# Altium schematic sheet SCH_WETBCV1XX.SchDoc(SCH_WETBCV1XX)
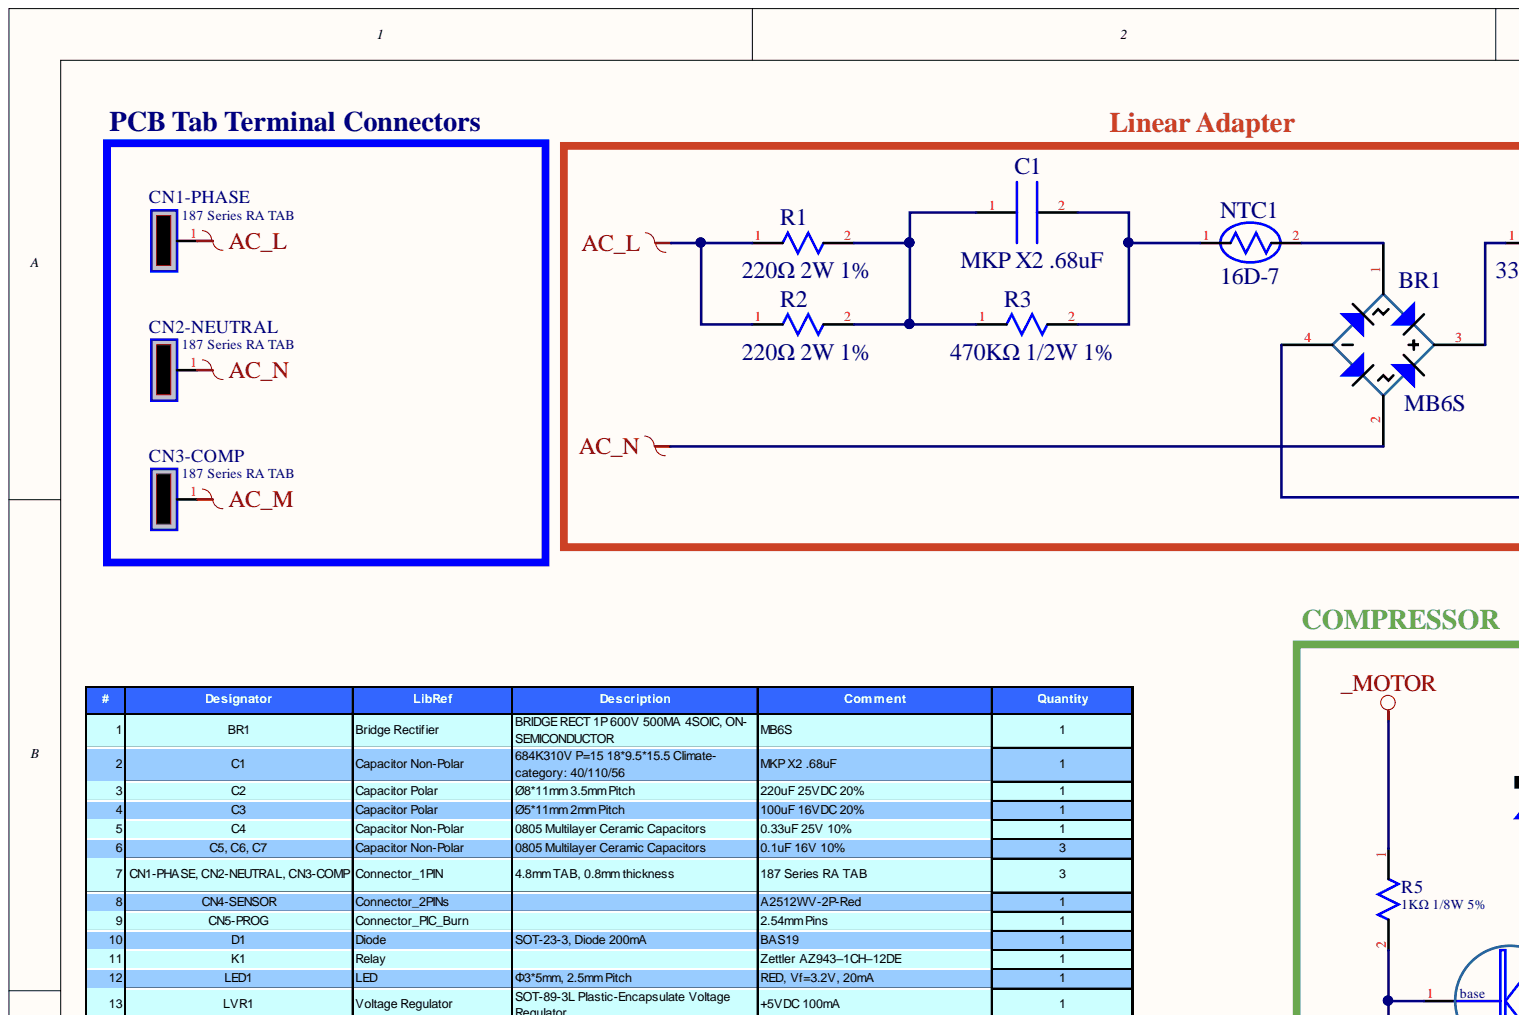
[diagram p0: SCH_WETBCV1XX.SchDoc, top left]
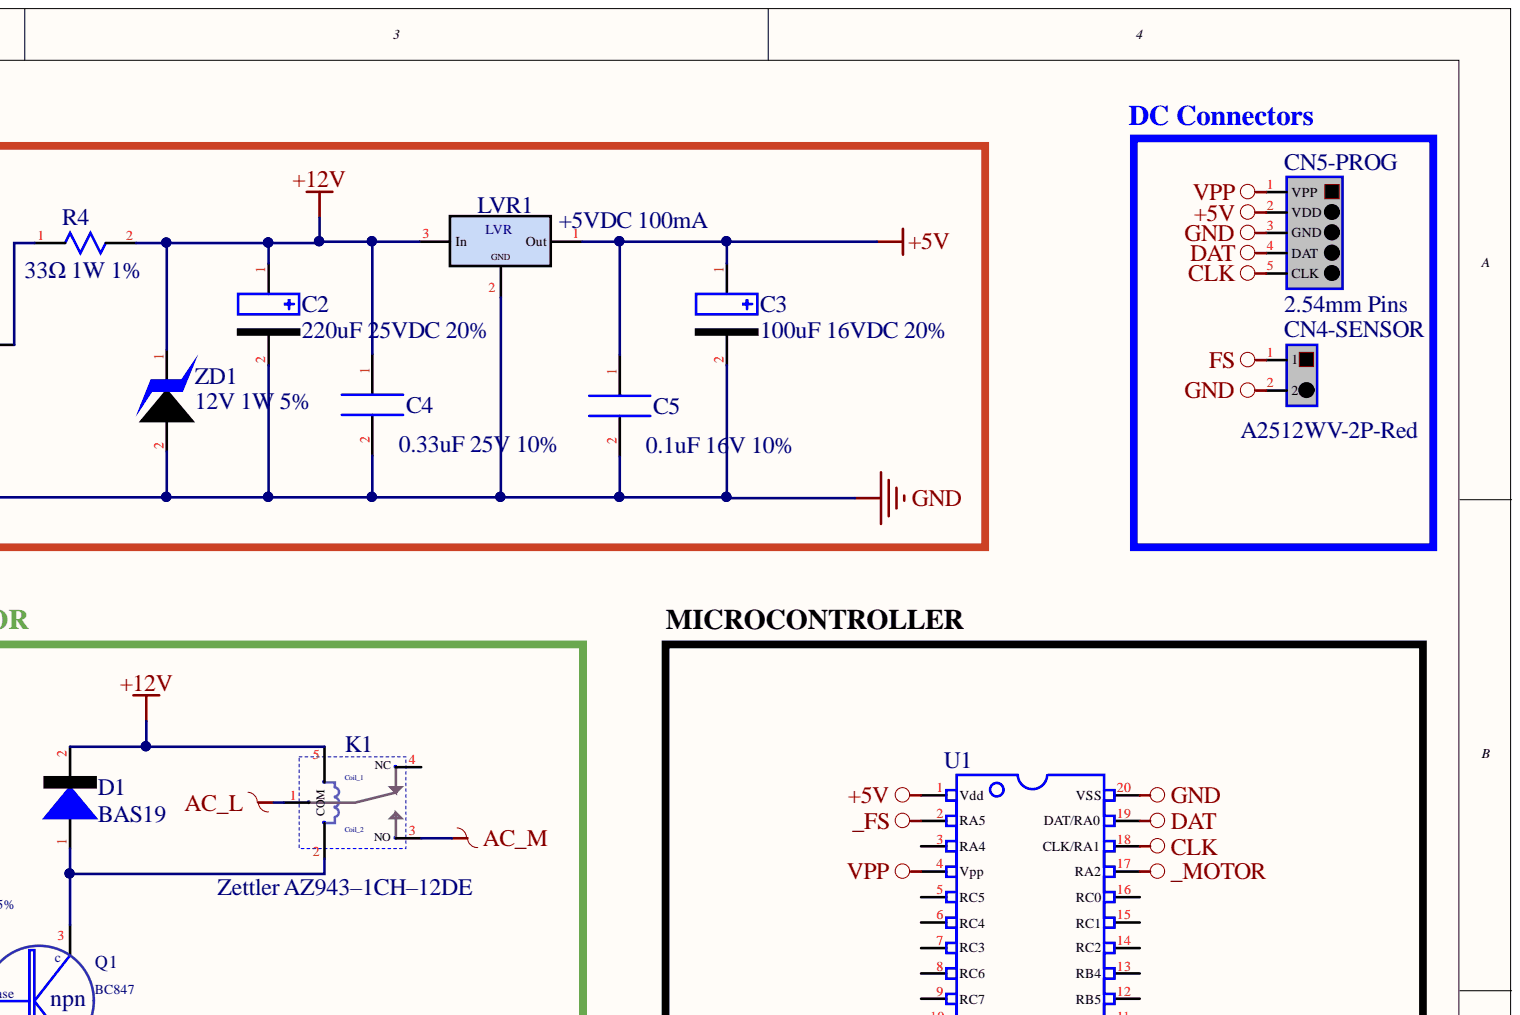
[diagram p1: SCH_WETBCV1XX.SchDoc, top right]
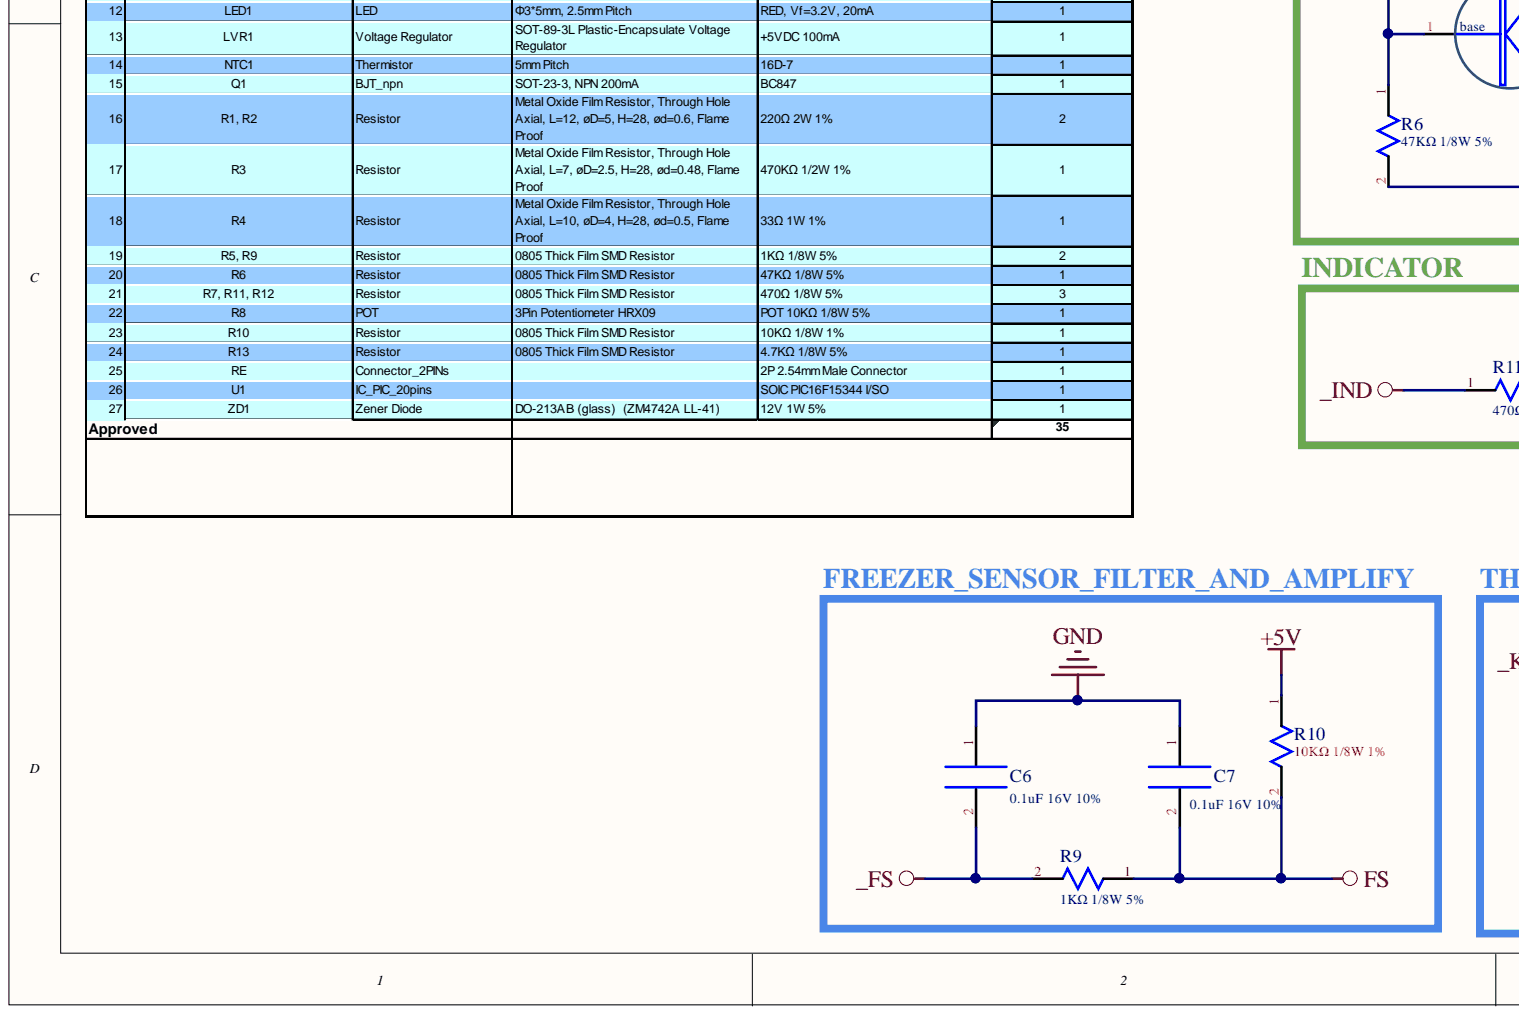
[diagram p2: SCH_WETBCV1XX.SchDoc, bottom left]
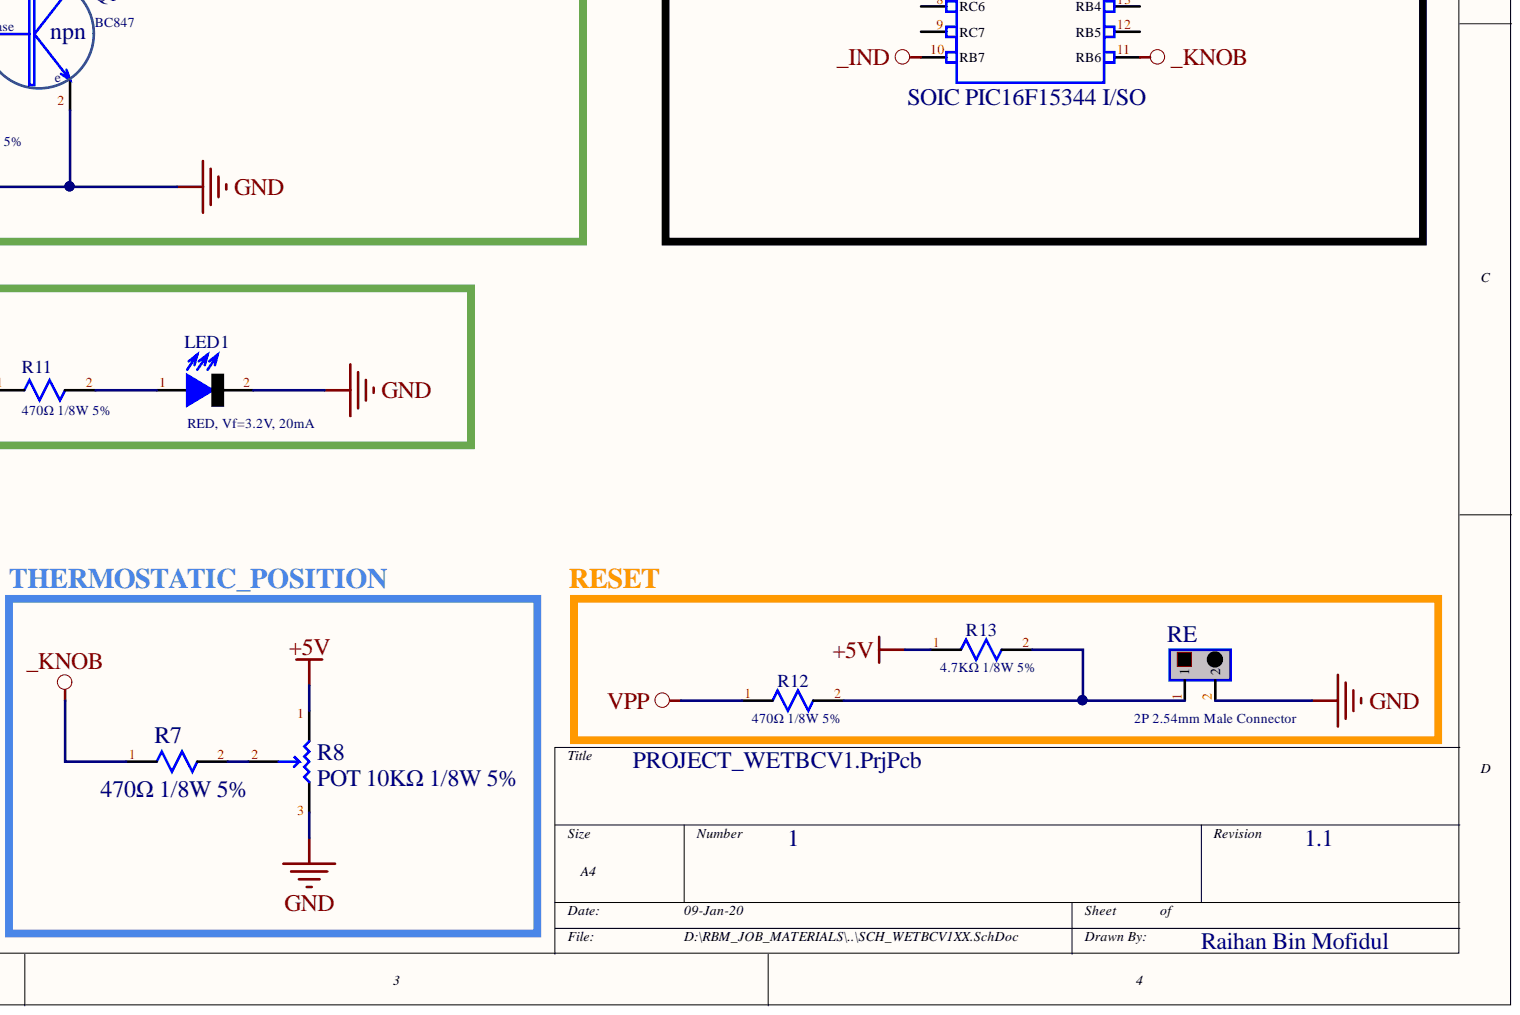
[diagram p3: SCH_WETBCV1XX.SchDoc, bottom right]

## Parts
BR1  MB6S  [BR_SMD_MB6S]
C1  MKP X2 .68uF  [C_X2_18X10X15.8_P15]
C2  220uF 25VDC 20%  [C_Radial_P3.5]
C3  100uF 16VDC 20%  [C_Radial_P2]
C4  0.33uF 25V 10%  [C_0805]
C5  0.1uF 16V 10%  [C_0805]
C6  0.1uF 16V 10%  [C_0805]
C7  0.1uF 16V 10%  [C_0805]
CN1-PHASE  187 Series RA TAB  [CN_Tab Terminal 187 series (Right Angle)]
CN2-NEUTRAL  187 Series RA TAB  [CN_Tab Terminal 187 series (Right Angle)]
CN3-COMP  187 Series RA TAB  [CN_Tab Terminal 187 series (Right Angle)]
CN4-SENSOR  A2512WV-2P-Red  [CN_B2B-XH-A_WHITE]
CN5-PROG  2.54mm Pins  [CN_PIC_MCU_Debugger_Straight]
D1  BAS19  [D_SOT-23-3_200mA]
K1  Zettler AZ943–1CH–12DE  [K_15ARelay_AZ943-1CH-12DE]
LED1  RED, Vf=3.2V, 20mA  [LED_3x5_P2.5]
LVR1  +5VDC 100mA  [U_CJ78L05_SOT89]
NTC1  16D-7  [NTC_5mmPitch]
Q1  BC847  [Q_SOT-23-3_NPN_BC847]
R1  220Ω 2W 1%  [R_Axial_P15]
R2  220Ω 2W 1%  [R_Axial_P15]
R3  470KΩ 1/2W 1%  [R_Axial_P10]
R4  33Ω 1W 1%  [R_Axial_P15]
R5  1KΩ 1/8W 5%  [R_0805]
R6  47KΩ 1/8W 5%  [R_0805]
R7  470Ω 1/8W 5%  [R_0805]
R8  POT 10KΩ 1/8W 5%  [R_POT]
R9  1KΩ 1/8W 5%  [R_0805]
R10  10KΩ 1/8W 1%  [R_0805]
R11  470Ω 1/8W 5%  [R_0805]
R12  470Ω 1/8W 5%  [R_0805]
R13  4.7KΩ 1/8W 5%  [R_0805]
RE  2P 2.54mm Male Connector  [CN_PIC_MCU_Reseter_Straight]
U1  SOIC PIC16F15344 I/SO  [U_PIC16F15344_SOIC]
ZD1  12V 1W 5%  [ZD_ZM4742A LL-41]

## Nets
+5V: C3.1 C5.1 CN5-PROG.2(VDD) LVR1.1 R8.1 R10.1 R13.1 U1.1(Vdd)
+12V: C2.1 C4.1 D1.2 K1.5 LVR1.3 R4.2 ZD1.1
_FS: C6.2 R9.2 U1.2(RA5)
_IND: R11.1 U1.10(RB7)
_KNOB: R7.1 U1.11(RB6)
_MOTOR: R5.1 U1.17(RA2)
AC_L: CN1-PHASE.1 K1.1 R1.1 R2.1
AC_M: CN3-COMP.1 K1.3(NO)
AC_N: BR1.2 CN2-NEUTRAL.1
CLK: CN5-PROG.5(CLK) U1.18(CLK/RA1)
DAT: CN5-PROG.4(DAT) U1.19(DAT/RA0)
FS: C7.2 CN4-SENSOR.1 R9.1 R10.2
GND: BR1.4 C2.2 C3.2 C4.2 C5.2 C6.1 C7.1 CN4-SENSOR.2 CN5-PROG.3(GND) LED1.2 LVR1.2 Q1.2 R6.2 R8.3 RE.2 U1.20(VSS) ZD1.2
VPP: CN5-PROG.1(VPP) R12.1 U1.4(Vpp)
NetBR1_1: BR1.1 NTC1.2
NetBR1_3: BR1.3 R4.1
NetC1_1: C1.1 R1.2 R2.2 R3.1
NetC1_2: C1.2 NTC1.1 R3.2
NetD1_1: D1.1 K1.2(COM) Q1.3
NetLED1_1: LED1.1 R11.2
NetQ1_1: Q1.1 R5.2 R6.1
NetR7_2: R7.2 R8.2
NetR12_2: R12.2 R13.2 RE.1

Not connected: K1 4(NC); U1 3(RA4) 5(RC5) 6(RC4) 7(RC3) 8(RC6) 9(RC7) 12(RB5) 13(RB4) 14(RC2) 15(RC1) 16(RC0)

## Library data of the parts
BR1 = Bridge Rectifier: BRIDGE RECT 1P 600V 500MA 4SOIC, ON-SEMICONDUCTOR; Component Kind: Standard; Library Name: RBM_SCH-LIB_02-SEP-19.SchLib
C1 = Capacitor Non-Polar: 684K310V P=15 18*9.5*15.5 Climate-category: 40/110/56; Component Kind: Standard; Library Name: RBM_SCH-LIB_02-SEP-19.SchLib
C2 = Capacitor Polar: Ø8*11mm 3.5mm Pitch; Component Kind: Standard; Library Name: RBM_SCH-LIB_02-SEP-19.SchLib
C3 = Capacitor Polar: Ø5*11mm 2mm Pitch; Component Kind: Standard; Library Name: RBM_SCH-LIB_02-SEP-19.SchLib
C4-C7 = Capacitor Non-Polar: 0805 Multilayer Ceramic Capacitors; Component Kind: Standard; Library Name: RBM_SCH-Lib_16-Apr-19.SchLib
CN1-PHASE CN2-NEUTRAL CN3-COMP = Connector_1PIN: 4.8mm TAB, 0.8mm thickness; Component Kind: Standard; Library Name: RBM_SCH-LIB_02-SEP-19.SchLib
CN4-SENSOR RE = Connector_2PINs: Component Kind: Standard
  CN4-SENSOR: Library Name: RBM_SCH-Lib_16-Apr-19.SchLib
  RE: Library Name: RBM_SCH-LIB_02-SEP-19.SchLib
CN5-PROG = Connector_PIC_Burn: Component Kind: Standard; Library Name: RBM_SCH-Lib_16-Apr-19.SchLib
D1 = Diode: SOT-23-3, Diode 200mA; Component Kind: Standard; Library Name: RBM_SCH-LIB_02-SEP-19.SchLib
K1 = Relay: Component Kind: Standard; Library Name: RBM_SCH-Lib_16-Apr-19.SchLib
LED1 = LED: Φ3*5mm, 2.5mm Pitch; Component Kind: Standard; Library Name: RBM_SCH-LIB_02-SEP-19.SchLib
LVR1 = Voltage Regulator: SOT-89-3L Plastic-Encapsulate Voltage Regulator; Component Kind: Standard; Library Name: RBM_SCH-Lib_16-Apr-19.SchLib
NTC1 = Thermistor: 5mm Pitch; Component Kind: Standard; Library Name: RBM_SCH-LIB_02-SEP-19.SchLib
Q1 = BJT_npn: SOT-23-3, NPN 200mA; Component Kind: Standard; Library Name: RBM_SCH-Lib_16-Apr-19.SchLib
R1 R2 = Resistor: Metal Oxide Film Resistor, Through Hole Axial, L=12, øD=5, H=28, ød=0.6, Flame Proof; Component Kind: Standard; Library Name: RBM_SCH-LIB_02-SEP-19.SchLib
R3 = Resistor: Metal Oxide Film Resistor, Through Hole Axial, L=7, øD=2.5, H=28, ød=0.48, Flame Proof; Component Kind: Standard; Library Name: RBM_SCH-LIB_02-SEP-19.SchLib
R4 = Resistor: Metal Oxide Film Resistor, Through Hole Axial, L=10, øD=4, H=28, ød=0.5, Flame Proof; Component Kind: Standard; Library Name: RBM_SCH-LIB_02-SEP-19.SchLib
R5-R7 R9-R13 = Resistor: 0805 Thick Film SMD Resistor; Component Kind: Standard
  R5 R6 R9-R13: Library Name: RBM_SCH-Lib_16-Apr-19.SchLib
  R7: Library Name: RBM_SCH-LIB_02-SEP-19.SchLib
R8 = POT: 3Pin Potentiometer HRX09; Component Kind: Standard; Library Name: RBM_SCH-LIB_02-SEP-19.SchLib
U1 = IC_PIC_20pins: Component Kind: Standard; Library Name: RBM_SCH-Lib_16-Apr-19.SchLib
ZD1 = Zener Diode: DO-213AB (glass)  (ZM4742A LL-41); Component Kind: Standard; Library Name: RBM_SCH-LIB_02-SEP-19.SchLib
